# Altium schematic sheet SensorFPC.SchDoc(SensorFPC)
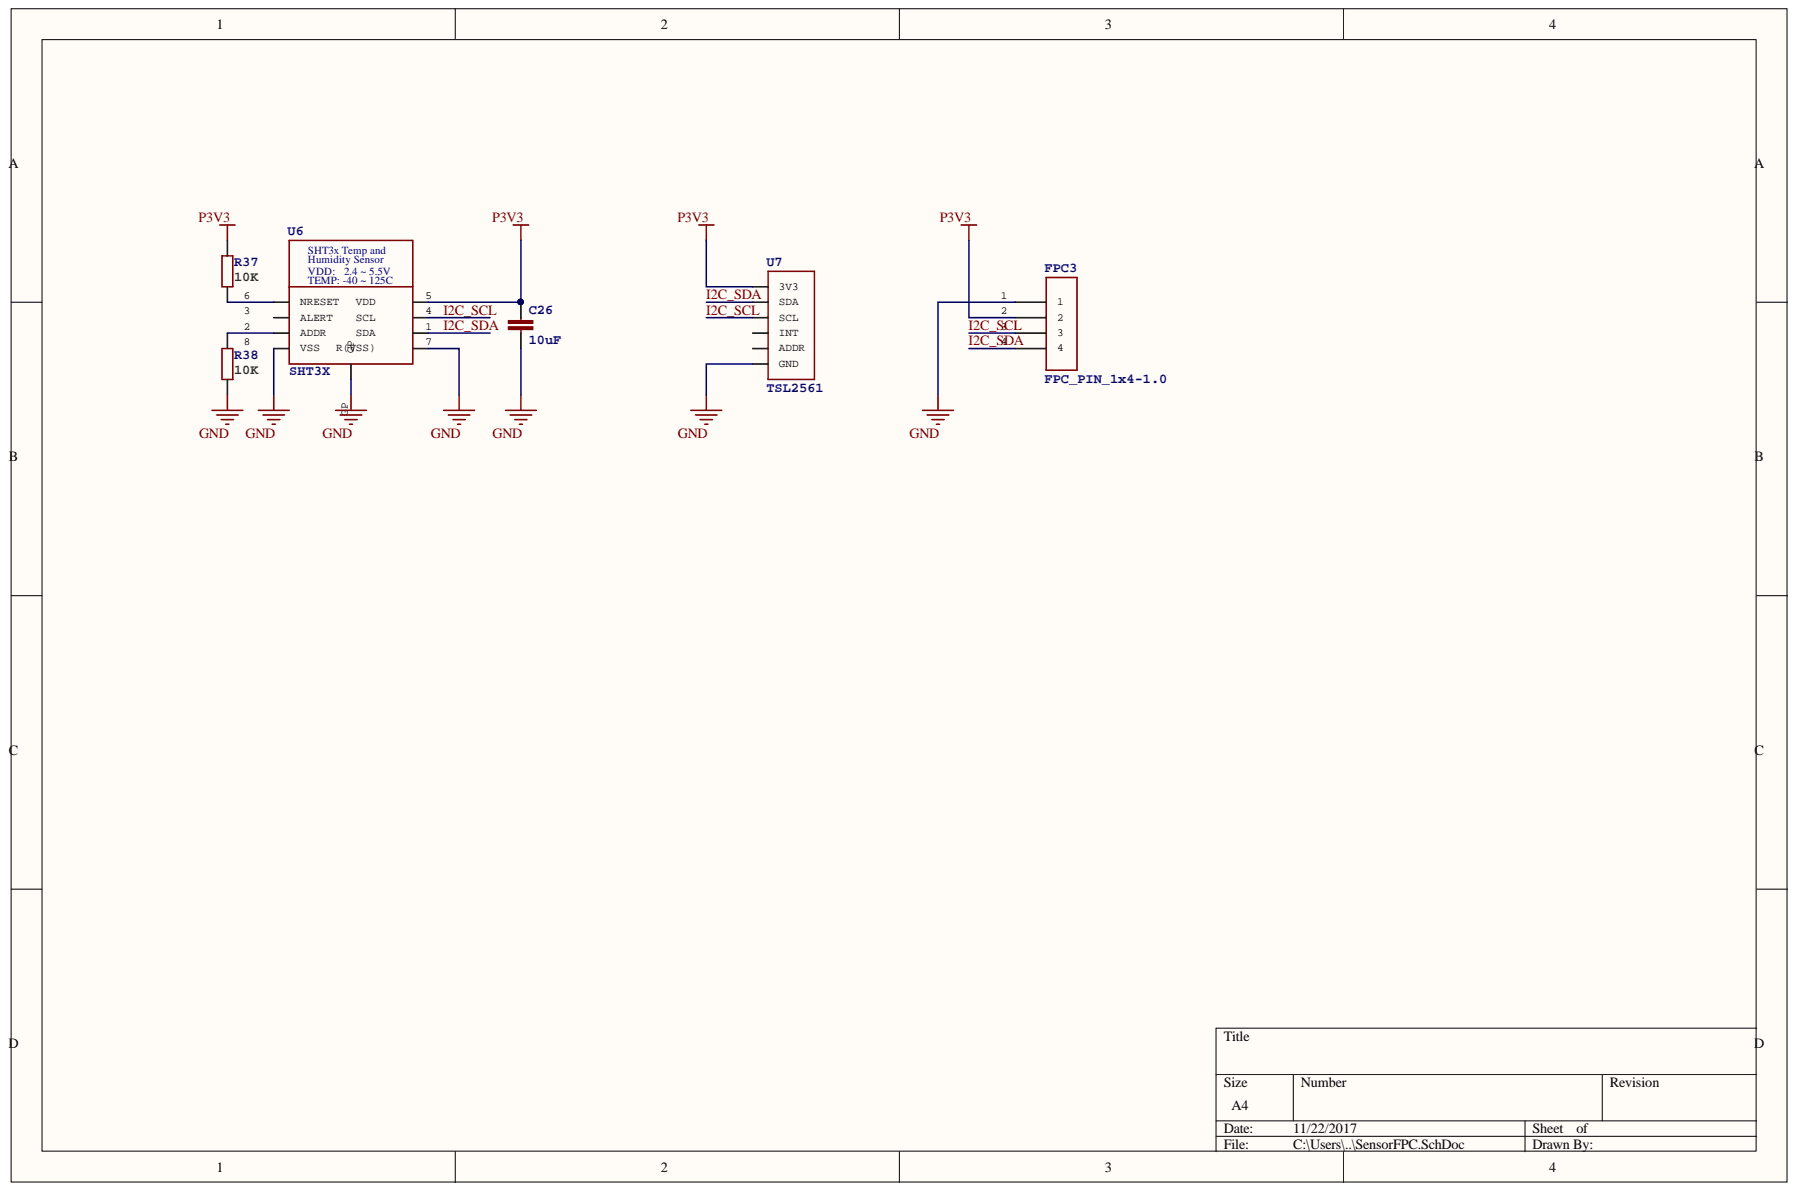
[diagram p0: SensorFPC.SchDoc]

## Parts
C26  10uF  [C0603]
FPC3  FPC_PIN_1x4-1.0  [FPC_PIN_1X4-1.0mm]
R37  10K  [R0603]
R38  10K  [R0603]
U6  SHT3X  [SHT3X]
U7  TSL2561  [TSL2561_FN]

## Nets
GND: C26.2 FPC3.1 R38.1 U6.7 U6.8(VSS) U6.GP U7.VSS(GND)
P3V3: C26.1 FPC3.2 R37.2 U6.5 U7.VDD(3V3)
I2C_SCL: FPC3.3 U6.4 U7.CLK(SCL)
I2C_SDA: FPC3.4 U6.1 U7.DATA(SDA)
NetR37_1: R37.1 U6.6(NRESET)
NetR38_2: R38.2 U6.2(ADDR)

Not connected: U6 3(ALERT); U7 ADR(ADDR) INT

## Library data of the parts
C26 = C-EUC0603: CAPACITOR, European symbol; Component Kind: Standard; Library Name: shenghua.SCHLIB
FPC3 = FPC_PIN_1x4-1.0: FPC_PIN_1x4-0.5; PART_NUMBER: MCR-B-S-RA-SMT-CS1TR; Component Kind: Standard; Library Name: shenghua.SCHLIB
R37 R38 = R-EU_R0603: Component Kind: Standard; Library Name: shenghua.SCHLIB
U6 = SHT3X: SHT3x - Digital Temperature and Humidity Sensor    Supply Voltage: 2.4-5.5V  Humidity Accuracy: SHT30 = +/-3%RH, SHT31 = +/-2%RH  Temperature Sensor: -40~125C; Component Kind: Standard; Library Name: shenghua.SCHLIB
U7 = TSL2561: TSL2561 Light-To-Digital Sensor, TSL2561FN; Component Kind: Standard; Library Name: shenghua.SCHLIB
